# Altium schematic sheet Vapeix_mini_connector.SchDoc
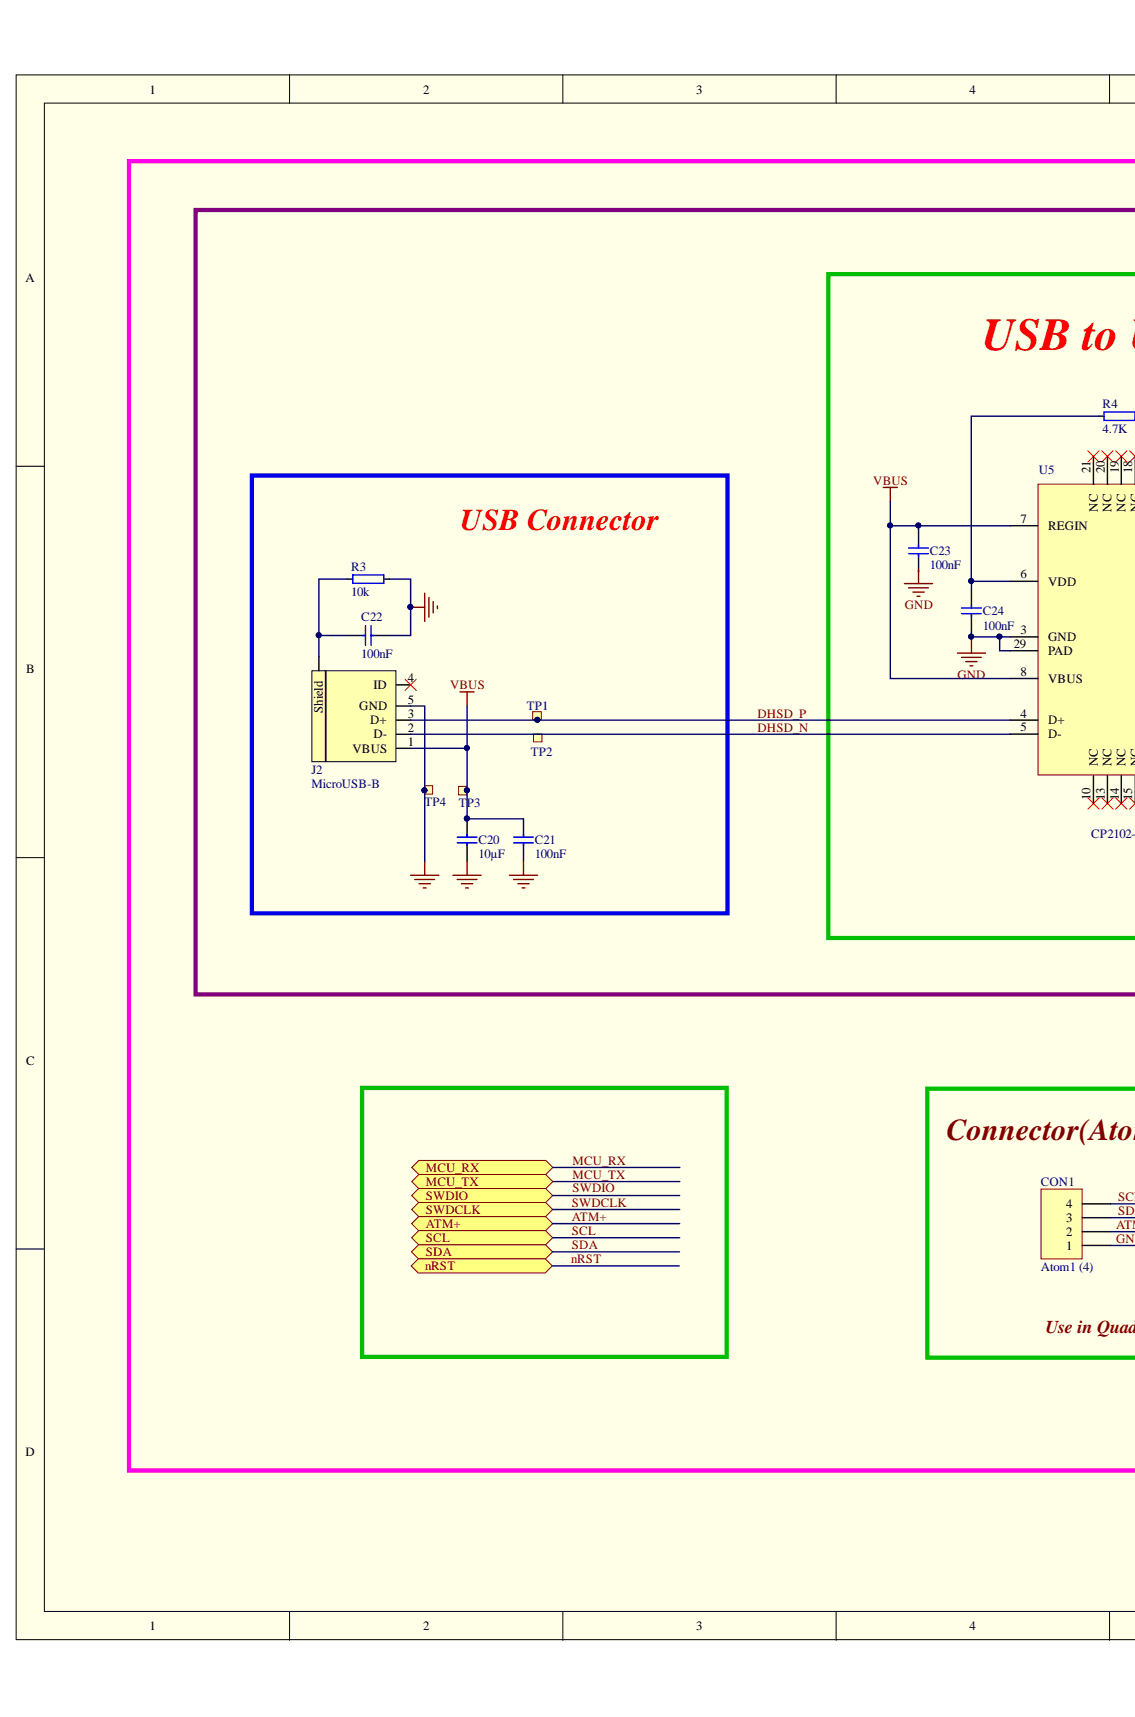
[diagram p0: Vapeix_mini_connector.SchDoc, left]
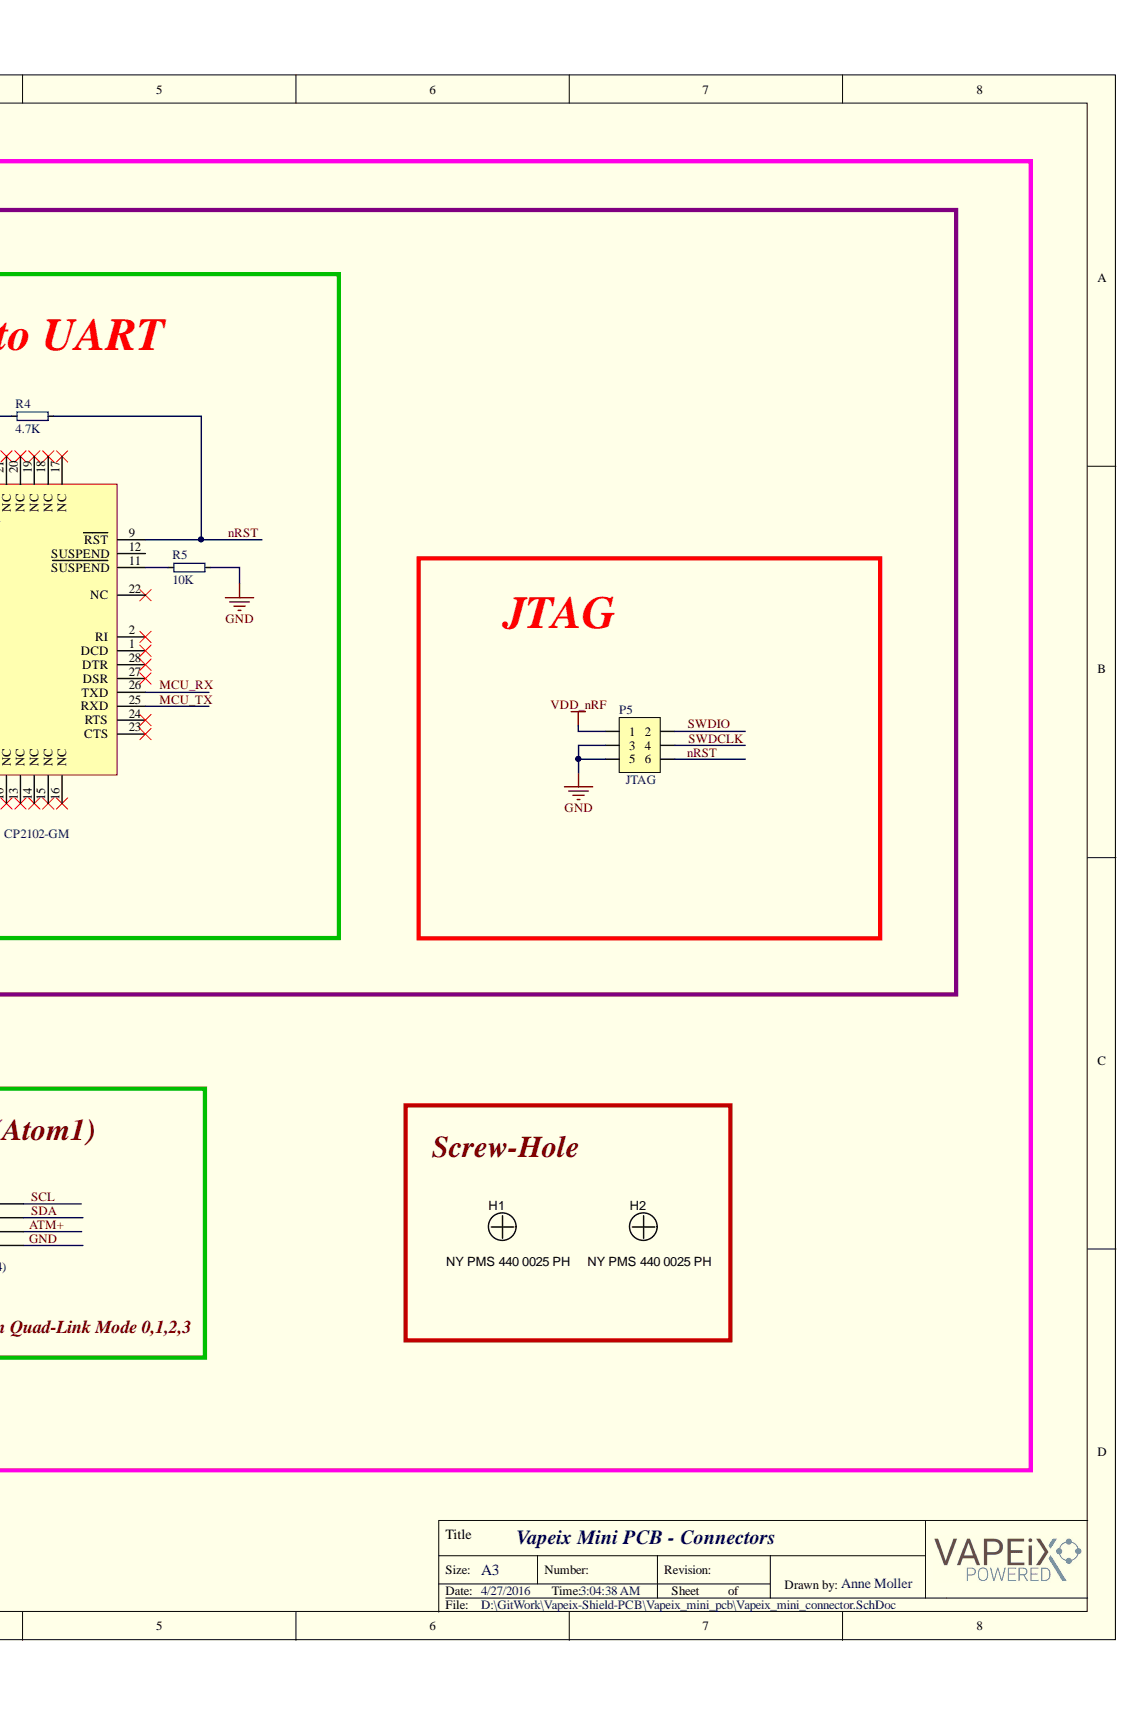
[diagram p1: Vapeix_mini_connector.SchDoc, right]

## Parts
C20  10µF  [CAPC1608X06L]
C21  100nF  [CAPC1005X04L]
C22  100nF  [CAPC1005X04L]
C23  100nF  [CAPC1005X04L]
C24  100nF  [CAPC1005X04L]
CON1  Atom1 (4)  [S4B-PH-K-S]
H1  NY PMS 440 0025 PH  [SCREW_HOLE2]
H2  NY PMS 440 0025 PH  [SCREW_HOLE2]
J2  MicroUSB-B  [FCI_10118193-0001LF]
P5  JTAG  [Molex 15-91-2060]
R3  10k  [RESC1005X04L]
R4  4.7K  [RESC1005X04L]
R5  10K  [RESC1005X04L]
TP1  Ø1.0mm,SMD  [TP_SMD_Ø1.0mm]  (value not drawn)  (no BOM)
TP2  Ø1.0mm,SMD  [TP_SMD_Ø1.0mm]  (value not drawn)  (no BOM)
TP3  Ø1.0mm,SMD  [TP_SMD_Ø1.0mm]  (value not drawn)  (no BOM)
TP4  Ø1.0mm,SMD  [TP_SMD_Ø1.0mm]  (value not drawn)  (no BOM)
U5  CP2102-GM  [CP2102-GM]

## Ports
ATM+, MCU_RX, MCU_TX, NRST, SCL, SDA, SWDCLK, SWDIO

## Nets
VBUS: C20.2 C21.2 C23.2 J2.1(VBUS) TP3.1 U5.7(REGIN) U5.8(VBUS)
VDD_NRF: P5.1
ATM+: CON1.2 ; port ATM+
DHSD_N: J2.2(D-) U5.5(D-)
DHSD_P: J2.3(D+) TP1.1 U5.4(D+)
GND: C20.1 C21.1 C22.1 C24.1 CON1.1 J2.5(GND) P5.3 P5.5 R3.1 R5.2 TP4.1 U5.3(GND) U5.29(PAD)
MCU_RX: U5.26(TXD) ; port MCU_RX
MCU_TX: U5.25(RXD) ; port MCU_TX
NRST: P5.6 R4.2 U5.9(RST) ; port NRST
SCL: CON1.4 ; port SCL
SDA: CON1.3 ; port SDA
SWDCLK: P5.4 ; port SWDCLK
SWDIO: P5.2 ; port SWDIO
NetC22_2: C22.2 J2.6(Shield) R3.2
NetC24_2: C24.2 R4.1 U5.6(VDD)
NetR5_1: R5.1 U5.11(SUSPEND)
CONNECTOR(ATOM1):
JTAG:
SCREW-HOLE:
USB CONNECTOR:
USB TO UART:
USE IN QUAD-LINK MODE 0,1,2,3:

Not connected: C23 1; J2 4(ID); TP2 1; U5 1(DCD) 2(RI) 10(NC) 12(SUSPEND) 13(NC) 14(NC) 15(NC) 16(NC) 17(NC) 18(NC) 19(NC) 20(NC) 21(NC) 22(NC) 23(CTS) 24(RTS) 27(DSR) 28(DTR)

## Library data of the parts
C20 = C0603-10µ: Capacitor, X5R, ±20%; Artikkelnr Noca: 20039234; Artikkelnr Simpro: N.A.; Database Table Name: Capacitors$; Note: 6.3V; Component Kind: Standard; Footprint Doc: 0603; LatestRevisionDate: 2011-01-17; Library Name: Nordic Passive DB.DbLib; Part Number: C0603-10µ; Pin Count: 2; Published: 2011-01-17; Value: 10µF; Publisher: Nordic Semiconductor
C21-C24 = C0402-100n: Capacitor, X7R, ±10%; Artikkelnr Noca: 20039200; Artikkelnr Simpro: N.A.; Database Table Name: Capacitors$; Note: 16V; Component Kind: Standard; Footprint Doc: 0402; LatestRevisionDate: 2009-11-23; Library Name: Nordic Passive DB.DbLib; Part Number: C0402-100n; Pin Count: 2; Published: 2009-05-08; Value: 100nF; Publisher: Nordic Semiconductor
CON1 = S4B-PH-K-S(LF)(SN): CONN HEADER PH SIDE 4POS 2MM; Manufacturer: JST Sales America Inc.; Connector Type: Header, Shrouded; Number of Positions: 4; Number of Positions Loaded: All; Pitch: 0.079" (2.00mm); Category: Connectors, Interconnects; Color: Natural; Component Kind: Standard; ComponentLink1Description: http://www.jst-mfg.com/product/pdf/eng/ePH.pdf; ComponentLink1URL: http://www.jst-mfg.com/product/pdf/eng/ePH.pdf; Contact Finish: Tin; Contact Finish Thickness: -; Contact Mating Length: 0.130" (3.30mm); Contact Type: Male Pin; Fastening Type: Detent Lock; Features: -; Manufacturer Part Number: S4B-PH-K-S(LF)(SN); Mounting Type: Through Hole, Right Angle; Number of Rows: 1; Packaging: Bulk; Pricing 1: 1=0.22, 10=0.208, 25=0.1772, 50=0.155, 100=0.144, 250=0.1274, 500=0.12186, 1000=0.0997, 2500=0.09139 (USD); RoHS: RoHS Compliant; Row Spacing: -; Stock 1: 41576; Supplier 1: Digi-Key; Termination: Press-Fit, Solder; Library Name: VapeixS_LIB.SchLib
H1 H2 = Screw-Hole: Machine Screw, Round, #4-40 x 1/4, Nylon, Philips panhead; Manufacturer: B&F Fastener Supply; CompType: Hardware; SymbolCreator: codlsc; U/M: EA; ClassName: Mounting Holes; Component Kind: Standard; ComponentLink1Description: Datasheet Link; ComponentLink1URL: http://www.fasnetdirect.com/refguide/Machinepancombo.pdf; DigiKeyPartNumber: H542-ND; LatestRevisionDate: 2014-05-15; MountType: N/A; PackageReference: Screw; PartNumber: NY PMS 440 0025 PH; RoHS: Y; Supplier 1: Digi-Key; Supplier Part Number 1: H542-ND; SymbolVersion: 2009-10-28; Library Name: VapeixS_LIB.SchLib; Publisher: Texas Instruments
J2 = FCI 10118193-0001LF: MicroUSB-B-SMT; 2nd source part number: 649-10118193-0001LF; Manufacturer: FCI; Artikkelnr Noca: N.A.; Database Table Name: Connectors$; 2nd source Supplier: Mouser; Artikkelnr Simpro: N.A.; Component Kind: Standard; Datasheet: http://portal.fciconnect.com/Comergent//fci/drawing/10118193.pdf; Footprint Doc: FCI_10118193-0001LF; LatestRevisionDate: 2014-10-07; Library Name: Nordic Passive DB.DbLib; Manufacturer Part Number: 10118193-0001LF; Part Number: FCI 10118193-0001LF; Pin Count: 5; Published: 2014-10-07; Supplier: Digi-Key; Supplier part number: 609-4616-1-ND; Value: MicroUSB-B; Publisher: Nordic Semiconductor
P5 = 0015912060: CONN HEADER 6POS .100" VERT TIN; Manufacturer: Molex, LLC; Connector Type: Header, Unshrouded, Breakaway; Number of Positions: 6; Number of Positions Loaded: All; Pitch: 0.100" (2.54mm); Category: Connectors, Interconnects; Color: Black; Component Kind: Standard; ComponentLink1Description: http://www.molex.com/pdm_docs/sd/015912060_sd.pdf; ComponentLink1URL: http://www.molex.com/pdm_docs/sd/015912060_sd.pdf; Contact Finish: Tin; Contact Finish Thickness: 150µin (3.81µm); Contact Mating Length: 0.240" (6.10mm); Contact Type: Male Pin; Fastening Type: -; Features: -; Manufacturer Part Number: 0015912060; Mounting Type: Surface Mount; Number of Rows: 2; Packaging: Bulk; Pricing 1: 1=0.43, 10=0.4, 25=0.36, 50=0.32, 100=0.3066, 250=0.27992, 500=0.2666, 1000=0.21995, 2500=0.19995 (USD); RoHS: RoHS Compliant; Row Spacing: 0.100" (2.54mm); Stock 1: 10294; Supplier 1: Digi-Key; Termination: Solder; Library Name: VapeixS_LIB.SchLib
R3 R5 = R0402-10k: Resistor, ±1%, 0.063W; Artikkelnr Noca: 27049097; Artikkelnr Simpro: N.A.; Database Table Name: Resistors$; Note: Chip Res 1%; Component Kind: Standard; Footprint Doc: 0402; LatestRevisionDate: 2009-11-23; Library Name: Nordic Passive DB.DbLib; Part Number: R0402-10k; Pin Count: 2; Published: 2009-05-08; Supplier: Farnell; Supplier part number: 173-8864; Value: 10k; Publisher: Nordic Semiconductor
R4 = R0402-10k: Resistor, ±1%, 0.063W; Artikkelnr Noca: 27049097; Artikkelnr Simpro: N.A.; Database Table Name: Resistors$; Note: Chip Res 1%; Value: 10k; Component Kind: Standard; Footprint Doc: 0402; LatestRevisionDate: 2009-11-23; Library Name: Nordic Passive DB.DbLib; Part Number: R0402-10k; Pin Count: 2; Published: 2009-05-08; Supplier: Farnell; Supplier part number: 173-8864; Publisher: Nordic Semiconductor
TP1-TP4 = TP_SMD_Ø1.0MM: 1.0mm circular SMD testpad; Manufacturer: Nordic Semiconductor; Artikkelnr Noca: N.A.; Database Table Name: Connectors$; Artikkelnr Simpro: N.A.; Footprint Doc: TP_SMD_Ø1.0mm; LatestRevisionDate: 2013-06-11; Library Name: Nordic Passive DB.DbLib; Part Number: TP_SMD_Ø1.0MM; Pin Count: 1; Published: 2013-06-11; Value: Ø1.0mm,SMD; Publisher: Nordic Semiconductor
U5 = CP2102-GM: SILICON LABS - CP2102-GM - USB-TO-UART BRIDGE, 3.6V, MLP-28; Base Number: 2102; Bridge Type: USB to UART; Data Rate: 1; full-speed (12 Mbps)</li><li class="features-and-benefits-list-item">USB suspend states supported via SUSPEND pins</li><li class="features-and-benefits-list-item">All handshaking and modem interface signals</li><li class="features-and-benefits-list-item">Baud rates: 300 bps to 1 Mbits</li><li class="features-and-benefits-list-item">576 Byte receive buffer; Interface Case Style: QFN; No. of Pins: 28; No. of Ports: 1 Port; no external crystal required</li><li class="features-and-benefits-list-item">Integrated 1024 Byte EEPROM</li><li class="features-and-benefits-list-item">On chip power on reset circuit</li><li class="features-and-benefits-list-item">On chip voltage regulator: 3.3V output</li><li class="features-and-benefits-list-item">USB Specification 2.0 compliant; Supply Voltage Max: 3.6; Supply Voltage Min: 3; Supply Voltage Range: 3V to 5.25V<!--FANDB--><div class="features-and-benefits-container"><div class="features-and-benefits-desc-container"><span class="features-and-benefits-container-desc">USB to UART Bridge Controller in QFN-28 Package</span></div><div class="features-and-benefits-description"><span class="features-benefits-value">The CP2102-GM is a highly integrated USB to UART Bridge Controller providing a simple solution for updating RS-232 designs to USB using a minimum of components and PCB space. The CP2102-GM includes a USB 2.0 full speed function controller, USB transceiver, oscillator, EEPROM, and asynchronous serial data bus (UART) with full modem control signals. No other external USB components are required. The on chip EEPROM may be used to customise the USB Vendor ID, Product ID, Product Description String, Power Descriptor, Device Release Number, and Device Serial Number as desired for OEM applications. The EEPROM is programmed on board via the USB, allowing the programming step to be easily integrated into the product manufacturing and testing process.</span></div><div class="features-and-benefits-list"><ul class="features-and-benefits-list-list"><li class="features-and-benefits-list-item">Integrated USB transceiver; USB Standard: USB 2.0; USB Type: USB UART; Component Kind: Standard; ComponentLink1Description: http://www.farnell.com/datasheets/1694195.pdf; ComponentLink1URL: http://www.farnell.com/datasheets/1694195.pdf; Logic Function Number: 2102; Manufacturer: SILICON LABS; Manufacturer Part Number: CP2102-GM; MSL: -; Operating Temperature Max: 85; Operating Temperature Min: -40; Operating Temperature Range: -40°C to +85; Packaging: Each; Pricing 1: 1=1.82, 10=1.72, 100=1.69, 250=1.66, 500=1.62, 2000=1.59, 3000=1.56, 5000=1.55 (GBP); RoHS: YES; Stock 1: 9194; Supplier 1: Farnell; Supplier Part Number 1: 9282130; Library Name: VapeixS_LIB.SchLib
